# Altium schematic sheet esp32.SchDoc
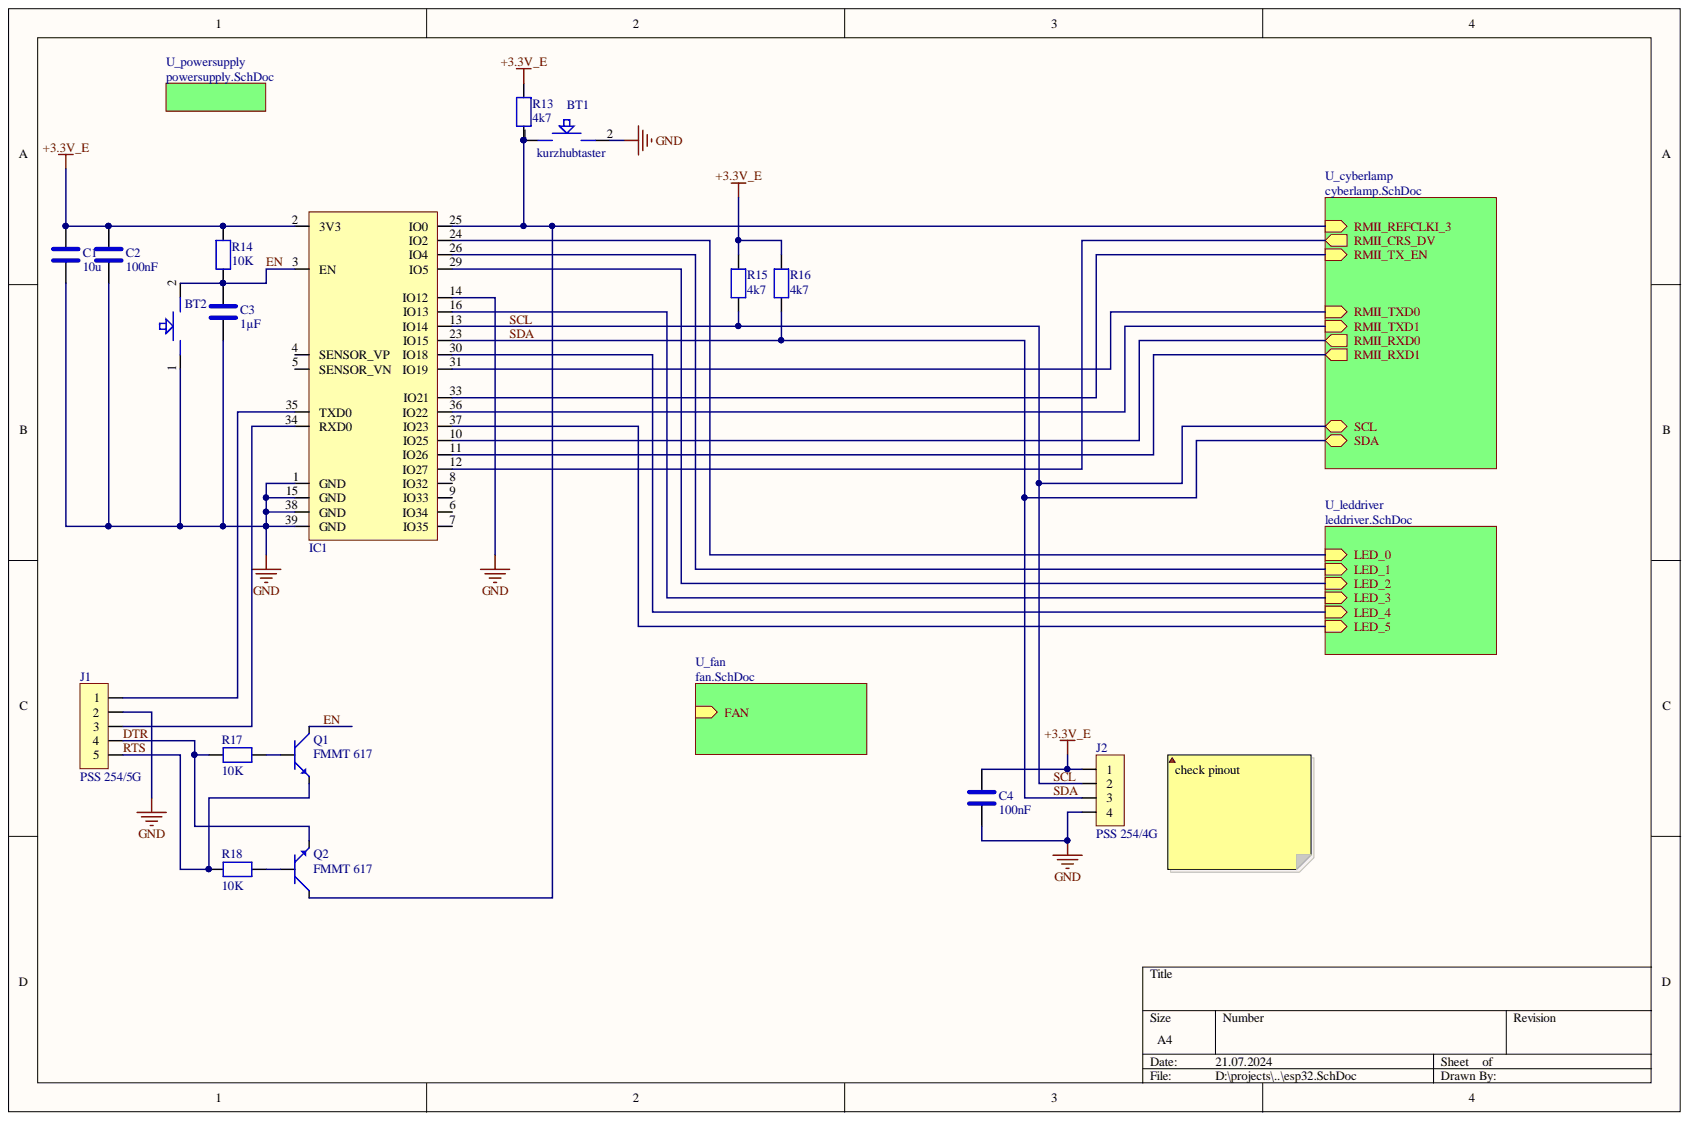
[diagram p0: esp32.SchDoc]

## Parts
BT1  kurzhubtaster  [kurzhubtaster]
BT2  kurzhubtaster  [kurzhubtaster]
C1  value 10u  [1210]  (hidden comment: 10uF 63V X7R 1210)
C2  value 100nF  [0603]  (hidden comment: 100nF 50V MLCC 0603)
C3  value 1µF  [0805]  (hidden comment: 1µF 25V MLCC 0805)
C4  value 100nF  [0603]  (hidden comment: 100nF 50V MLCC 0603)
IC1  ESP32-WROVER-E  [ESP32-WROVER-I]  (value not drawn)
J1  PSS 254/5G  [PSS 5pol]
J2  PSS 254/4G  [PSS 4pol]
Q1  FMMT 617  [SOT-23]
Q2  FMMT 617  [SOT-23]
R13  value 4k7  [res0603]  (hidden comment: 4k7 100mW 0603)
R14  value 10K  [res0603]  (hidden comment: 10K 100mW 0603)
R15  value 4k7  [res0603]  (hidden comment: 4k7 100mW 0603)
R16  value 4k7  [res0603]  (hidden comment: 4k7 100mW 0603)
R17  value 10K  [res0603]  (hidden comment: 10K 100mW 0603)
R18  value 10K  [res0603]  (hidden comment: 10K 100mW 0603)

## Nets
+3.3V_E: C1.1 C2.1 C4.1 IC1.2(3V3) J2.1 R13.2 R14.2 R15.2 R16.2
GND: BT1.2 BT2.1 C1.2 C2.2 C3.2 C4.2 IC1.1(GND) IC1.14(IO12) IC1.15(GND) IC1.38(GND) IC1.39(GND) J1.2 J2.4
DTR: J1.4 Q2.2 R17.2
EN: BT2.2 C3.1 IC1.3(EN) Q1.3 R14.1
RTS: J1.5 Q1.2 R18.2
SCL: IC1.13(IO14) J2.2 R15.1
SDA: IC1.23(IO15) J2.3 R16.1
NetBT1_1: BT1.1 IC1.25(IO0) Q2.3 R13.1
NetIC1_34: IC1.34(RXD0) J1.3
NetIC1_35: IC1.35(TXD0) J1.1
NetQ1_1: Q1.1 R17.1
NetQ2_1: Q2.1 R18.1
FAN:

Not connected: IC1 4(SENSOR_VP) 5(SENSOR_VN) 6(IO34) 7(IO35) 8(IO32) 9(IO33) 10(IO25) 11(IO26) 12(IO27) 16(IO13) 24(IO2) 26(IO4) 29(IO5) 30(IO18) 31(IO19) 33(IO21) 36(IO22) 37(IO23)

## Library data of the parts
BT1 BT2 = kurzhubtaster: reichelt code: TASTER 3301D; Component Kind: Standard; Library Name: switch_relais.IntLib
C2 C4 = 100nF 50V MLCC 0603: dielectricum: X7R; Reichelt code: X7R-G0603 100N; Component Kind: Standard; Description: 100nF 50V MLCC 0603; lcsc code: C2252264; Library Name: capacitors.IntLib; mouser code: 80-C0603X104K3R; storage: AIDTEKBox | Röhrchen; storageID: 18 | F7; voltage: 50V
C3 = 1µF 25V MLCC 0805: dielectricum: X5R; Reichelt code: KEM X5R0805 1,0U; Component Kind: Standard; Description: 1µF 25V MLCC 0805; lcsc code: C35795; Library Name: capacitors.IntLib; voltage: 25V
IC1 = ESP32-WROVER-E-N16R2: Component Kind: Standard; Library Name: microcontroller.IntLib; mouser code: 356-ESP32WRVREN16R2
J1 = PSS 254/5G: PSS 5pol wire-to-board-connector; Component Kind: Standard; Library Name: connectors_pss.IntLib; reichelt code: PSS 254/5G
J2 = PSS 254/4G: PSS 4pol wire-to-board-connector; Component Kind: Standard; Library Name: connectors_pss.IntLib; reichelt code: PSS 254/4G
Q1 Q2 = FMMT 617: NPN switching Transistor, 3A; reichelt code: FMMT 617 TA; Component Kind: Standard; Library Name: semiconductors.IntLib
R14 R17 R18 = 10K 100mW 0603: Reichelt code: SMD-0603 10K; Tolerance: 1%; Component Kind: Standard; Description: 10K 100mW 0603; Library Name: resistors.IntLib; mouser code: 603-RE0603FRE0710KL; Power rating: 100mW; storage: AIDTEKBox; storageID: 4
C1 = 10uF 63V X7R 1210: Component Kind: Standard; Description: 10uF 63V X7R 1210; lcsc code: C703129; Library Name: capacitors.IntLib; mouser part: 81-GRM32ER71J106MA2L; voltage: 63V
R13 R15 R16 = 4k7 100mW 0603: Component Kind: Standard; Description: 4k7 100mW 0603; Library Name: resistors.IntLib; mouser code: 603-RE0603FRE074K7L; Power rating: 100mW; storage: AIDTEKBox; storageID: 5
